# Altium schematic sheet DCCD_Bridge.SchDoc(DCCD_Bridge)
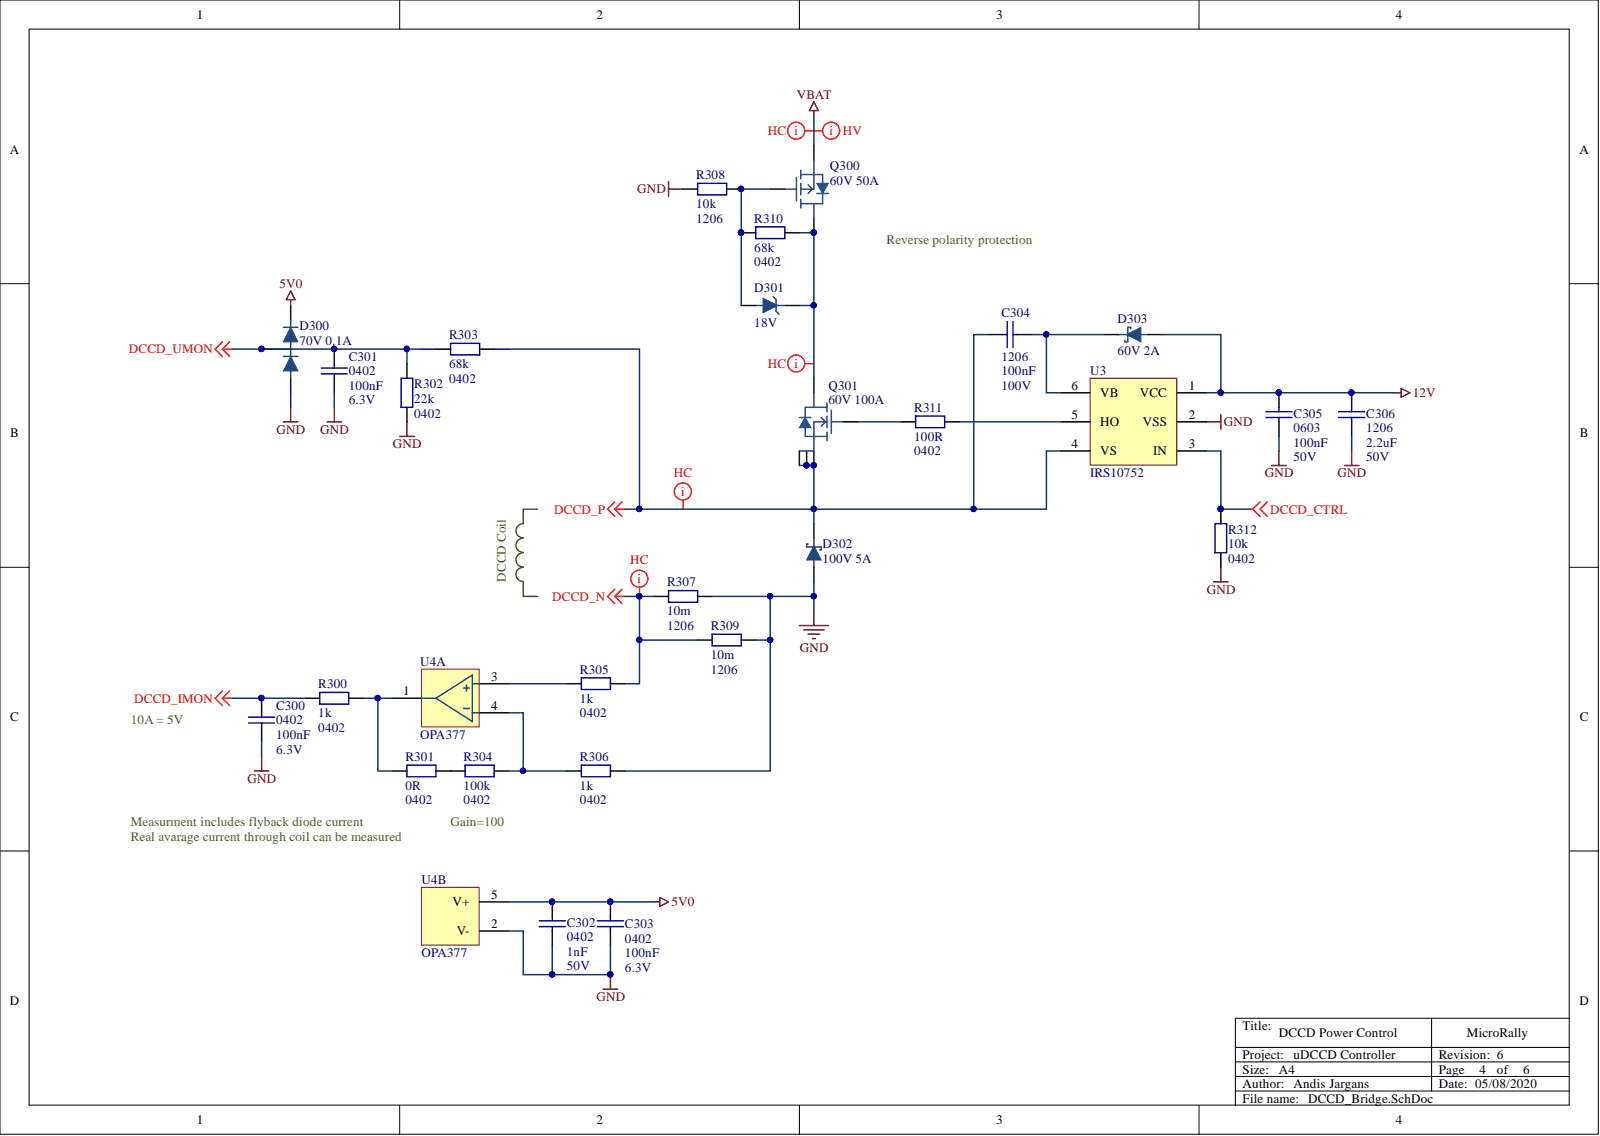
[diagram p0: DCCD_Bridge.SchDoc]

## Parts
C300  100n  value 100nF  PACKAGE: 0402  _VOLTAGE: 6.3V  [C 0402]
C301  100n  value 100nF  PACKAGE: 0402  _VOLTAGE: 6.3V  [C 0402]
C302  1n  value 1nF  PACKAGE: 0402  _VOLTAGE: 50V  [C 0402]
C303  100n  value 100nF  PACKAGE: 0402  _VOLTAGE: 6.3V  [C 0402]
C304  100n  value 100nF  PACKAGE: 1206  [C 1206]
C305  100n  value 100nF  PACKAGE: 0603  _VOLTAGE: 50V  [C 0603]
C306  2.2u  value 2.2uF  PACKAGE: 1206  _VOLTAGE: 50V  [C 1206]
D300  value 70V 0.1A  _VOLTAGE: 70V  [SOT23-3]  (hidden comment: SBAS70-04LT1G)
D301  value 18V  [SOT23-3]  (hidden comment: BZX84C18)
D302  value 100V 5A  _VOLTAGE: 100V  [DO-214AC]  (hidden comment: HTA5U100)
D303  value 60V 2A  _VOLTAGE: 60V  _IF: 2A  [SOD-123FL]  (hidden comment: NTS260ESFT1G)
Q300  value 60V 50A  _VDS: 60V  [TO252-3]  (hidden comment: SUD50P06-15)
Q301  value 60V 100A  _VDS: 60V  _CURRENT: 100A  [SOT-669]  (hidden comment: PSMN5R5-60YS)
R300  1k  PACKAGE: 0402  [R 0402]
R301  0  value 0R  PACKAGE: 0402  [R 0402]
R302  22k  PACKAGE: 0402  [R 0402]
R303  68k  [R 0402]
R304  100k  PACKAGE: 0402  [R 0402]
R305  1k  PACKAGE: 0402  [R 0402]
R306  1k  PACKAGE: 0402  [R 0402]
R307  10m  [R 1206]
R308  10k  PACKAGE: 1206  [R 1206]
R309  10m  PACKAGE: 1206  [R 1206]
R310  68k  [R 0402]
R311  100  value 100R  PACKAGE: 0402  [R 0402]
R312  10k  PACKAGE: 0402  [R 0402]
U3  IRS10752  [SOT23-6]
U4  OPA377  [SOT23-5]  (parts A,B)

## Nets
5V0: C302.1 C303.1 D300.2 U4.5(V+)
12V: C305.1 C306.1 D303.2 U3.1(VCC)
DCCD_CTRL: R312.2 U3.3(IN)
DCCD_IMON: C300.1 R300.1
DCCD_N: R305.2 R307.1 R309.1
DCCD_P: C304.1 D302.1 Q301.1 Q301.2 Q301.3 R303.1 U3.4(VS)
DCCD_UMON: C301.1 D300.3 R302.2 R303.2
GND: C300.2 C301.2 C302.2 C303.2 C305.2 C306.2 D300.1 D302.2 R302.1 R306.2 R307.2 R308.1 R309.2 R312.1 U3.2(VSS) U4.2(V-)
VBAT: Q300.4
NetC304_2: C304.2 D303.1 U3.6(VB)
NetD301_1: D301.1 Q300.1 R308.2 R310.1
NetD301_3: D301.3 Q300.3 Q301.MB R310.2
NetQ301_4: Q301.4 R311.1
NetR300_2: R300.2 R301.1 U4.1
NetR301_2: R301.2 R304.1
NetR304_2: R304.2 R306.1 U4.4
NetR305_1: R305.1 U4.3
NetR311_2: R311.2 U3.5(HO)

## Library data of the parts
C300 C301 C303 = C_100_6.3V_0402: Capacitor 0402 100nF 6.3V; _TOLERANCE: 10%; Library Name: Capacitors Ceramic.IntLib; Component Kind: Standard; _CAPACITANCE: 100nF
C302 = C_1_50V_0402: Capacitor 0402 1nF 50V; _TOLERANCE: 10%; Library Name: Capacitors Ceramic.IntLib; Component Kind: Standard; _CAPACITANCE: 1nF
C304 = C_100_100V_1206: Capacitor 1206 100nF 100V; _TOLERANCE: 10%; Library Name: Capacitors Ceramic.IntLib; Component Kind: Standard; _VOLTAGE: 100V; _CAPACITANCE: 100nF
C305 = C_100_50V_0603: Capacitor 0603 100nF 50V; _TOLERANCE: 10%; Library Name: Capacitors Ceramic.IntLib; Component Kind: Standard; _CAPACITANCE: 100nF
C306 = C_2200_50V_1206: Capacitor 1206 2.2uF 50V; _TOLERANCE: 10%; Library Name: Capacitors Ceramic.IntLib; Component Kind: Standard; _CAPACITANCE: 2.2uF
D300 = D DS 70V 0.1A SOT23: Dual Series diode SOT23 70V 100mA; _POWER: 225mW; _IF: 0.1A; VALUE: 70V 0.1A; PART#: SBAS70-04LT1G; PACKAGE: SOT23-3; Library Name: Diodes.IntLib; Component Kind: Standard
D301 = D ZE 18V SOT-23: Zener Diode, 18V @5mA, SOT-23; PART#: BZX84C18LT1G; _POWER: 250mW; PACKAGE: SOT-23; Library Name: Diodes.IntLib; Component Kind: Standard; _VOLTAGE: 18V
D302 = D SC 100V 5A DO-214AC: Schottky Rectifier, 100 V, 5 A, Single, DO-214AC; _IF: 5A; PART#: HTA5U100; PACKAGE: DO-214AC; Library Name: Diodes.IntLib; Component Kind: Standard
D303 = D SC 60V 2A SOD123: Schottky Rectifier, 60 V, 2 A, Single, SOD-123FL; _POWER: 225mW; PART#: NTS260ESFT1G; PACKAGE: SOD123FL; Library Name: Diodes.IntLib; Component Kind: Standard
Q300 = Q MOS-P 60V 50A: MOSFET-P 60V 50A TO252; _VGS Treshlod: 3V; _VGS: 20V; _POWER: 113W / 2.5W; PART#: SUD50P06-15; PACKAGE: TO-252; Library Name: Transistors.IntLib; Component Kind: Standard; _TYPE: MOSFET-P; _CURRENT: 50A
Q301 = Q MOS-N 60V 100A: MOSFET-N 60V 100A SOT-669; _VGS Treshlod: 3V; _VGS: 20V; _POWER: 130W; PART#: PSMN5R5-60YS; PACKAGE: SOT-669; Library Name: Transistors.IntLib; Component Kind: Standard; _TYPE: MOSFET-N
R300 R305 R306 = R_1000_0402: Resistor 0402 1k 1/16W; _TOLERANCE: 1%; _POWER: 62.5mW; VALUE: 1k; Library Name: Resistors Chip.IntLib; Component Kind: Standard
R301 = R_0_0402: Resistor 0402 0R 1/16W; _TOLERANCE: 1%; _POWER: 62.5mW; Library Name: Resistors Chip.IntLib; Component Kind: Standard
R302 = R_22000_0402: Resistor 0402 22k 1/16W; _TOLERANCE: 1%; _POWER: 62.5mW; VALUE: 22k; Library Name: Resistors Chip.IntLib; Component Kind: Standard
R303 R310 = R_68000_0402: Resistor 0402 68k 1/16W; _TOLERANCE: 1%; _POWER: 62.5mW; VALUE: 68k; PACKAGE: 0402; Library Name: Resistors Chip.IntLib; Component Kind: Standard
R304 = R_100000_0402: Resistor 0402 100k 1/16W; _TOLERANCE: 1%; _POWER: 62.5mW; VALUE: 100k; Library Name: Resistors Chip.IntLib; Component Kind: Standard
R307 R309 = R_0.01_1206: Resistor 1206 10m 1W; _TOLERANCE: 1%; VALUE: 10m; Library Name: Resistors Chip.IntLib; Component Kind: Standard; _POWER: 1W
  R307: PACKAGE: 1206
R308 = R_10000_1206: Resistor 1206 10k 1/10W; _TOLERANCE: 1%; _POWER: 250mW; VALUE: 10k; Library Name: Resistors Chip.IntLib; Component Kind: Standard
R311 = R_100_0402: Resistor 0402 100R 1/16W; _TOLERANCE: 1%; _POWER: 62.5mW; Library Name: Resistors Chip.IntLib; Component Kind: Standard
R312 = R_10000_0402: Resistor 0402 10k 1/16W; _TOLERANCE: 1%; _POWER: 62.5mW; VALUE: 10k; Library Name: Resistors Chip.IntLib; Component Kind: Standard
U3 = IRS10752LPBF: SOT-23 High-Side Gate Driver IC; PART#: IRS10752LTRPBF; _VOLTAGE: 10-18V; VALUE: IRS10752; PACKAGE: SOT23-6; Library Name: Drivers.IntLib; Component Kind: Standard; _TYPE: Gate
U4 = OPA377: 5MHz, Low-Noise, Single, Dual, Quad CMOS Operational Amplifiers; PART#: OPA377AIDBVT; _VOLTAGE: 2.2V..5.5V; _TYPE: High Precision; _RAIL TO RAIL: IN,OUT; VALUE: OPA377; PACKAGE: SOT23-5; Library Name: OpAmps.IntLib; Component Kind: Standard
